# Altium schematic sheet PIN(11~12).SchDoc(PIN(11~12))
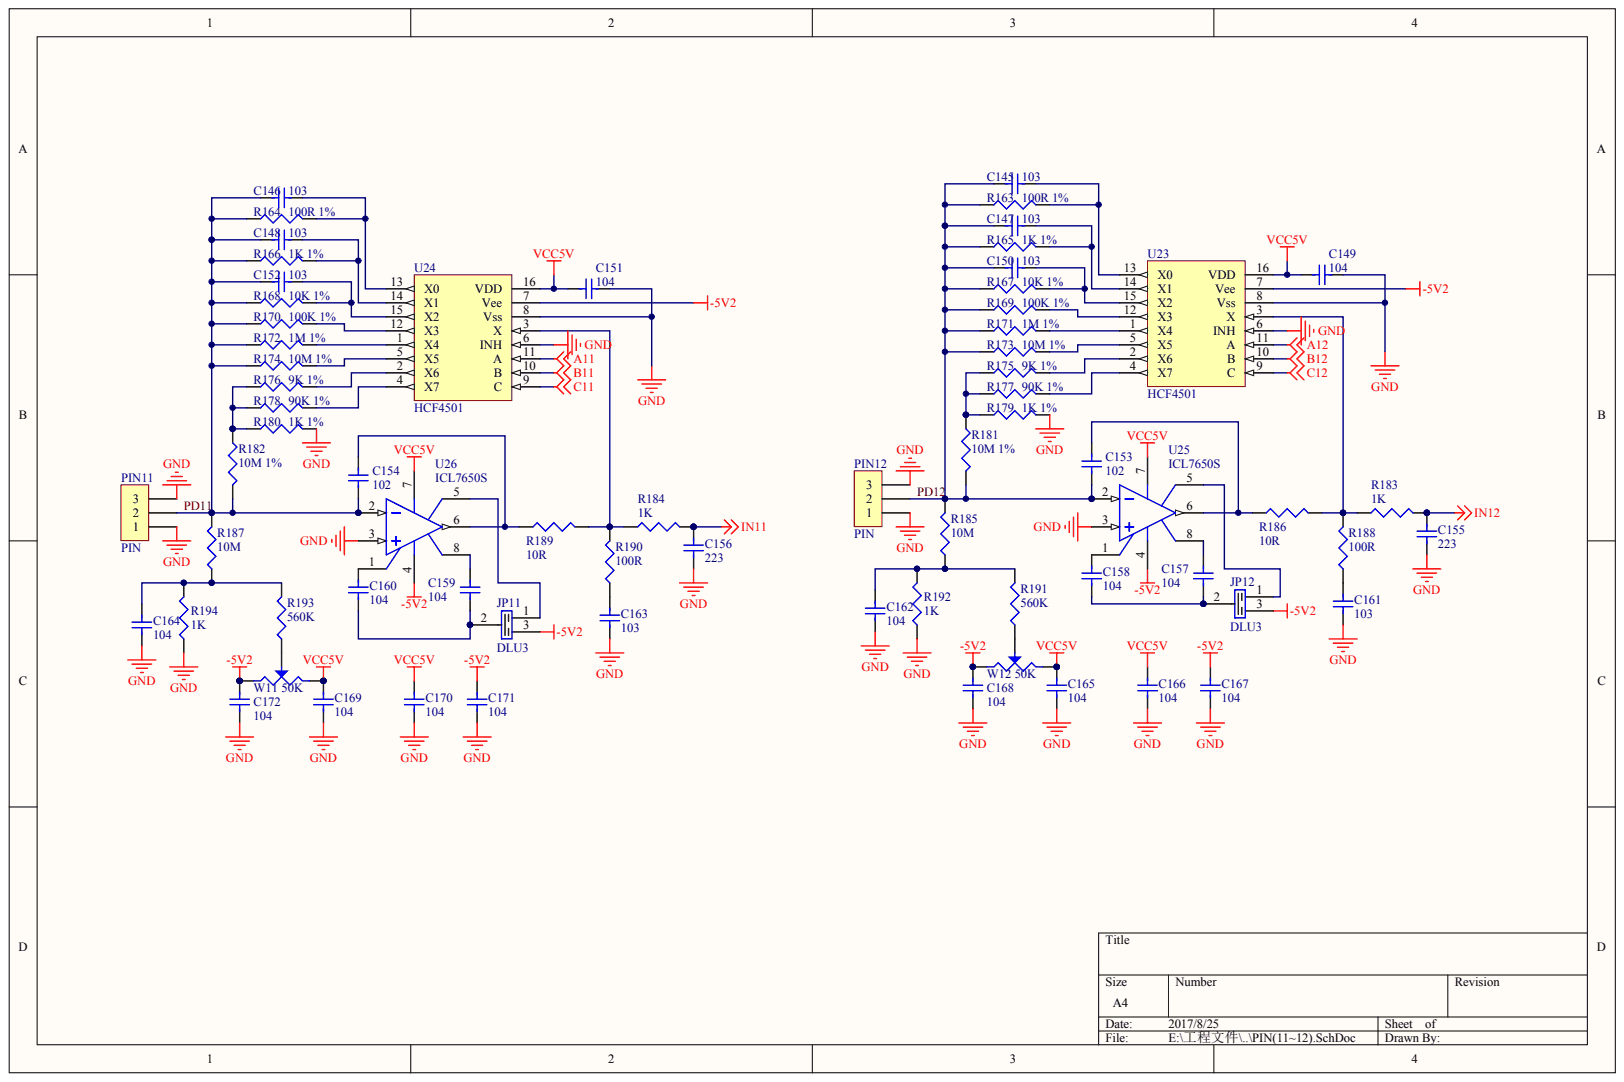
[diagram p0: PIN]

## Parts
C145  103
C146  103
C147  103
C148  103
C149  104
C150  103
C151  104
C152  103
C153  102
C154  102
C155  223
C156  223
C157  104
C158  104
C159  104
C160  104
C161  103
C162  104
C163  103
C164  104
C165  104
C166  104
C167  104
C168  104
C169  104
C170  104
C171  104
C172  104
JP11  DLU3
JP12  DLU3
PIN11  PIN
PIN12  PIN
R163  100R 1%
R164  100R 1%
R165  1K 1%
R166  1K 1%
R167  10K 1%
R168  10K 1%
R169  100K 1%
R170  100K 1%
R171  1M 1%
R172  1M 1%
R173  10M 1%
R174  10M 1%
R175  9K 1%
R176  9K 1%
R177  90K 1%
R178  90K 1%
R179  1K 1%
R180  1K 1%
R181  10M 1%
R182  10M 1%
R183  1K
R184  1K
R185  10M
R186  10R
R187  10M
R188  100R
R189  10R
R190  100R
R191  560K
R192  1K
R193  560K
R194  1K
U23  HCF4501
U24  HCF4501
U25  ICL7650S
U26  ICL7650S
W11  50K
W12  50K

## Nets
-5V2: C167.2 C168.1 C171.2 C172.1 JP11.3 JP12.3 U23.7(Vee) U24.7(Vee) U25.4 U26.4 W11.1 W12.1
A11: U24.11(A)
A12: U23.11(A)
B11: U24.10(B)
B12: U23.10(B)
C11: U24.9(C)
C12: U23.9(C)
GND: C149.2 C151.2 C155.1 C156.1 C161.1 C162.1 C163.1 C164.1 C165.1 C166.1 C167.1 C168.2 C169.1 C170.1 C171.1 C172.2 PIN11.1 PIN11.3 PIN12.1 PIN12.3 R179.2 R180.2 R192.1 R194.1 U23.6(INH) U23.8(Vss) U24.6(INH) U24.8(Vss) U25.3 U26.3
IN11: C156.2 R184.2
IN12: C155.2 R183.2
VCC5V: C149.1 C151.1 C165.2 C166.2 C169.2 C170.2 U23.16(VDD) U24.16(VDD) U25.7 U26.7 W11.3 W12.3
PD11: C146.1 C148.1 C152.1 C154.1 PIN11.2 R164.1 R166.1 R168.1 R170.1 R172.1 R174.1 R182.2 R187.2 U26.2
PD12: C145.1 C147.1 C150.1 C153.1 PIN12.2 R163.1 R165.1 R167.1 R169.1 R171.1 R173.1 R181.2 R185.2 U25.2
NetC145_2: C145.2 R163.2 U23.13(X0)
NetC146_2: C146.2 R164.2 U24.13(X0)
NetC147_2: C147.2 R165.2 U23.14(X1)
NetC148_2: C148.2 R166.2 U24.14(X1)
NetC150_2: C150.2 R167.2 U23.15(X2)
NetC152_2: C152.2 R168.2 U24.15(X2)
NetC153_2: C153.2 R186.1 U25.6
NetC154_2: C154.2 R189.1 U26.6
NetC157_1: C157.1 U25.8
NetC157_2: C157.2 C158.1 JP12.2
NetC158_2: C158.2 U25.1
NetC159_1: C159.1 U26.8
NetC159_2: C159.2 C160.1 JP11.2
NetC160_2: C160.2 U26.1
NetC161_2: C161.2 R188.1
NetC162_2: C162.2 R185.1 R191.2 R192.2
NetC163_2: C163.2 R190.1
NetC164_2: C164.2 R187.1 R193.2 R194.2
NetJP11_1: JP11.1 U26.5
NetJP12_1: JP12.1 U25.5
NetR169_2: R169.2 U23.12(X3)
NetR170_2: R170.2 U24.12(X3)
NetR171_2: R171.2 U23.1(X4)
NetR172_2: R172.2 U24.1(X4)
NetR173_2: R173.2 U23.5(X5)
NetR174_2: R174.2 U24.5(X5)
NetR175_1: R175.1 R177.1 R179.1 R181.1
NetR175_2: R175.2 U23.2(X6)
NetR176_1: R176.1 R178.1 R180.1 R182.1
NetR176_2: R176.2 U24.2(X6)
NetR177_2: R177.2 U23.4(X7)
NetR178_2: R178.2 U24.4(X7)
NetR183_1: R183.1 R186.2 R188.2 U23.3(X)
NetR184_1: R184.1 R189.2 R190.2 U24.3(X)
NetR191_1: R191.1 W12.2
NetR193_1: R193.1 W11.2
